# Altium schematic sheet Outputs.SchDoc(Outputs)
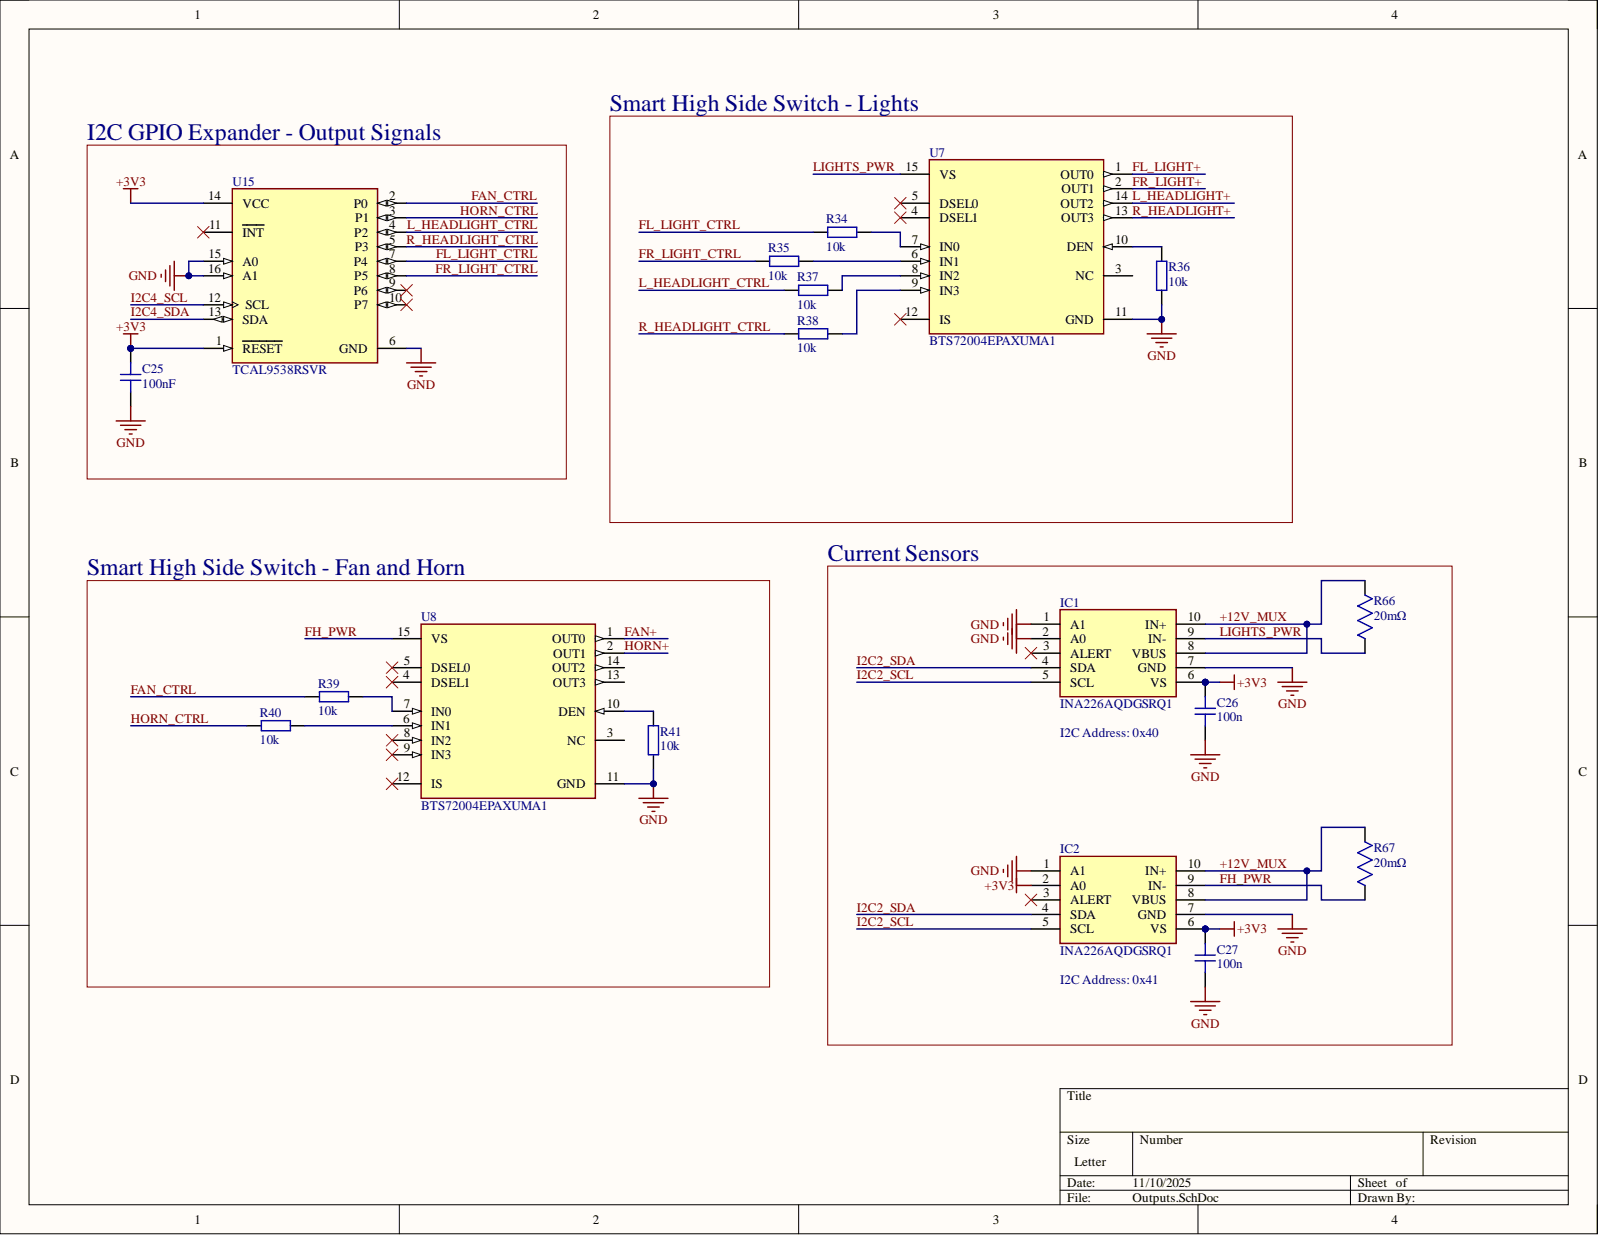
[diagram p0: Outputs.SchDoc]

## Parts
C25  100nF
C26  100n
C27  100n
IC1  INA226AQDGSRQ1
IC2  INA226AQDGSRQ1
R34  10k
R35  10k
R36  10k
R37  10k
R38  10k
R39  10k
R40  10k
R41  10k
R66  value 20mΩ  (hidden comment: WSLP0805R0200DEA)
R67  value 20mΩ  (hidden comment: WSLP0805R0200DEA)
U7  BTS72004EPAXUMA1
U8  BTS72004EPAXUMA1
U15  TCAL9538RSVR

## Nets
+3V3: C25.2 C26.2 C27.2 IC1.6(VS) IC2.2(A0) IC2.6(VS) U15.1(RESET) U15.14(VCC)
GND: C25.1 C26.1 C27.1 IC1.1(A1) IC1.2(A0) IC1.7(GND) IC2.1(A1) IC2.7(GND) R36.1 R41.1 U7.11(GND) U8.11(GND) U15.6(GND) U15.15(A0) U15.16(A1)
+12V_MUX: IC1.8(VBUS) IC1.10(IN+) IC2.8(VBUS) IC2.10(IN+) R66.2 R67.2
FAN+: U8.1(OUT0)
FAN_CTRL: R39.2 U15.2(P0)
FH_PWR: IC2.9(IN-) R67.1 U8.15(VS)
FL_LIGHT+: U7.1(OUT0)
FL_LIGHT_CTRL: R34.2 U15.7(P4)
FR_LIGHT+: U7.2(OUT1)
FR_LIGHT_CTRL: R35.2 U15.8(P5)
HORN+: U8.2(OUT1)
HORN_CTRL: R40.2 U15.3(P1)
I2C2_SCL: IC1.5(SCL) IC2.5(SCL)
I2C2_SDA: IC1.4(SDA) IC2.4(SDA)
I2C4_SCL: U15.12(SCL)
I2C4_SDA: U15.13(SDA)
L_HEADLIGHT+: U7.14(OUT2)
L_HEADLIGHT_CTRL: R37.2 U15.4(P2)
LIGHTS_PWR: IC1.9(IN-) R66.1 U7.15(VS)
R_HEADLIGHT+: U7.13(OUT3)
R_HEADLIGHT_CTRL: R38.2 U15.5(P3)
NetR34_1: R34.1 U7.7(IN0)
NetR35_1: R35.1 U7.6(IN1)
NetR36_2: R36.2 U7.10(DEN)
NetR37_1: R37.1 U7.8(IN2)
NetR38_1: R38.1 U7.9(IN3)
NetR39_1: R39.1 U8.7(IN0)
NetR40_1: R40.1 U8.6(IN1)
NetR41_2: R41.2 U8.10(DEN)

Not connected: IC1 3(ALERT); IC2 3(ALERT); U7 3(NC) 4(DSEL1) 5(DSEL0) 12(IS); U8 3(NC) 4(DSEL1) 5(DSEL0) 8(IN2) 9(IN3) 12(IS) 13(OUT3) 14(OUT2); U15 9(P6) 10(P7) 11(INT)

## Library data of the parts
C25 = CAP_0603_16V: Capacitor SMD 0603 16V; Component Kind: Standard; Library Name: Schematic-Lib-PhilsLab.SchLib
C26 C27 = CAP_0603: Capacitor SMD 0603; Component Kind: Standard; Library Name: Schematic-Lib-PhilsLab (1).SchLib
IC1 IC2 = CMP-031-00023-1: AEC-Q100; Manufacturer_Name: Texas Instruments; Arrow Part Number: INA226AQDGSRQ1; Arrow Price/Stock: https://www.arrow.com/en/products/ina226aqdgsrq1/texas-instruments?region=nac; Component Kind: Standard; Datasheet Link: http://www.ti.com/lit/gpn/ina226-q1; Design Item ID: INA226AQDGSRQ1; Height: 1.1mm; Manufacturer_Part_Number: INA226AQDGSRQ1; Mouser Part Number: 595-INA226AQDGSRQ1; Mouser Price/Stock: https://www.mouser.co.uk/ProductDetail/Texas-Instruments/INA226AQDGSRQ1?qs=uNh9J9Y3wTf1B1%2FBFFp7SA%3D%3D; Source: SamacSys.SchLib; Library Name: Solar Gators
R34-R41 = RES_0603: Resistor SMD 0603; Component Kind: Standard; Library Name: Schematic-Lib-PhilsLab (1).SchLib
R66 R67 = CMP-009-00198-1: 0.02Ω shunt resistor; Power: 500mW; Tolerance: 0.5%; Case/Package: 0805; Component Kind: Standard; Max Operating Temperature: 170°C; Min Operating Temperature: -65°C; Mounting Technology: Surface Mount; Pins: 2; RoHS Compliant: Yes; Library Name: Solar Gators
U7 U8 = CMP-32849-000007-1: IC 4CH HIGH SIDE SWITCH; Component Kind: Standard; Library Name: Altium Content Vault
U15 = CMP-00370-145347764-1: TCAL9538RSVR Low-voltage 8-bit I²C-bus/SMBus I/O expander with interrupt, reset and agile I/O configuration 16-UQFN -40 to 125; Manufacturer Lifecycle Status: ACTIVE; Component Kind: Standard; Height: 550µm; Length: 2.6mm; Library Name: Altium Content Vault; Lifecycle Status: Production; NexarPartId: 145347764; Number of Pins: 16; Thickness: 500µm; Width: 1.8mm
